# Altium schematic sheet ESP8266_Amp_SMD.SchDoc(ESP8266_Amp_SMD)
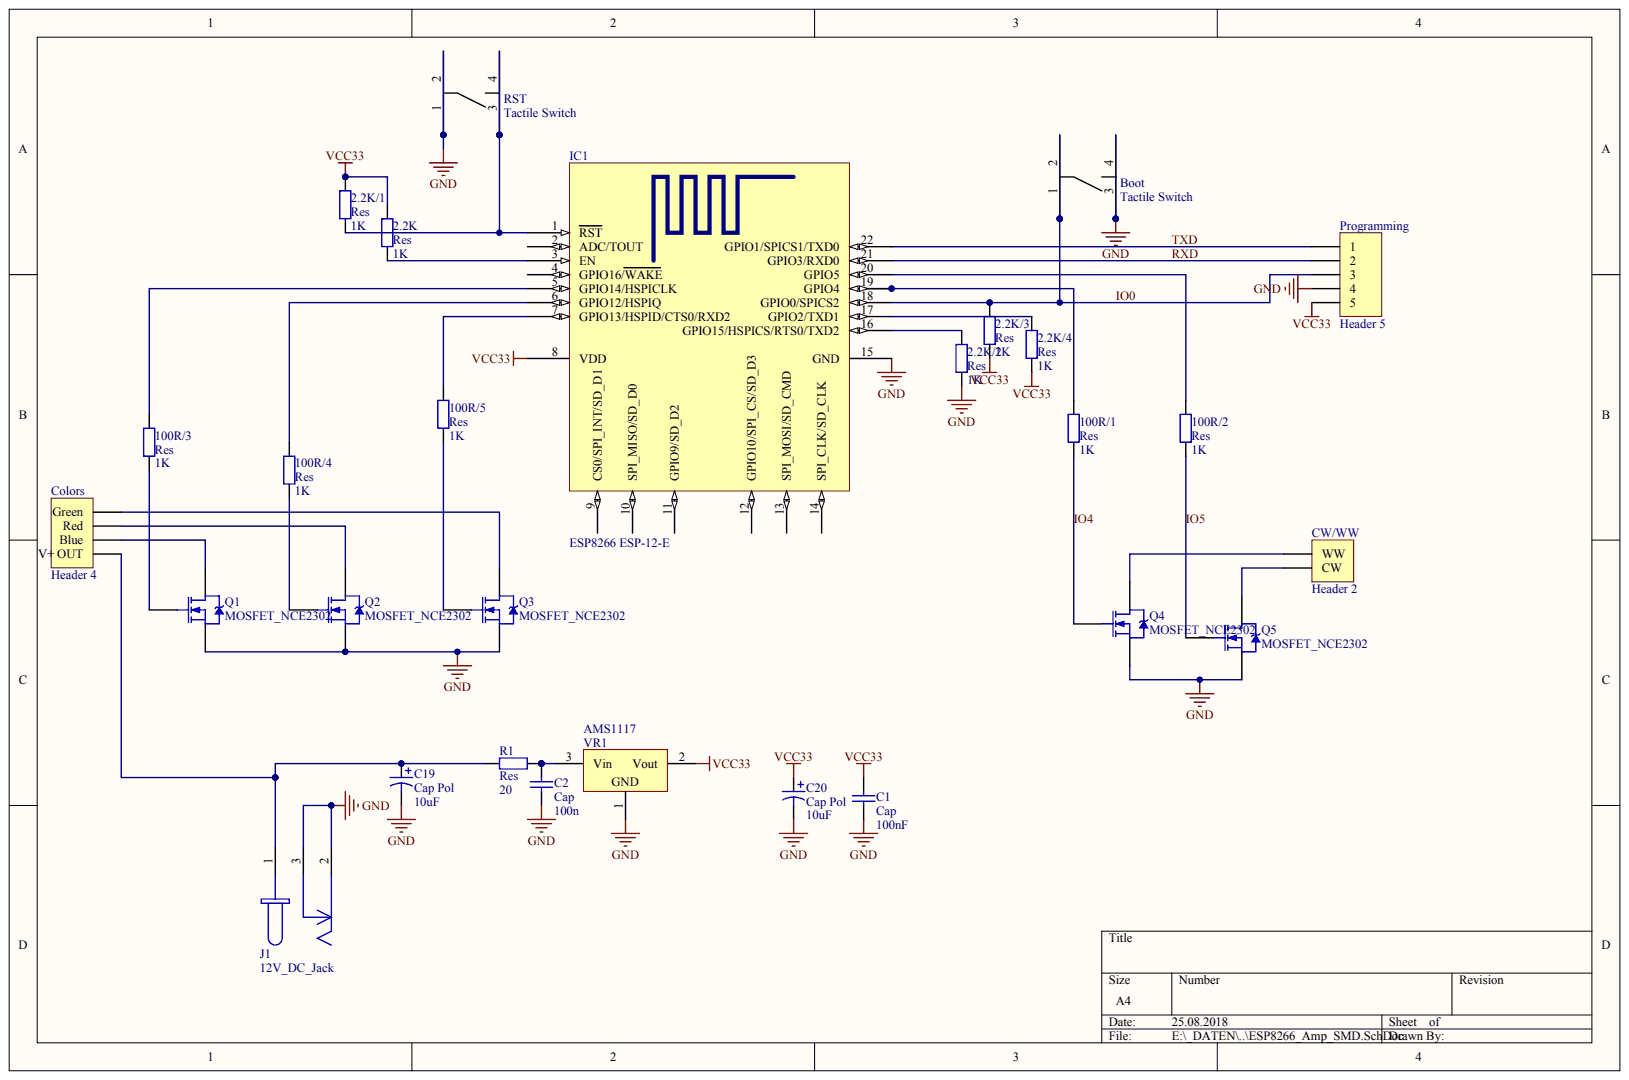
[diagram p0: ESP8266_Amp_SMD.SchDoc]

## Parts
2.2K  Res  value 1K
2.2K/1  Res  value 1K
2.2K/2  Res  value 1K
2.2K/3  Res  value 1K
2.2K/4  Res  value 1K
100R/1  Res  value 1K
100R/2  Res  value 1K
100R/3  Res  value 1K
100R/4  Res  value 1K
100R/5  Res  value 1K
Boot  Tactile Switch
C1  Cap  value 100nF
C2  Cap  value 100n
C19  Cap Pol  value 10uF
C20  Cap Pol  value 10uF
Colors  Header 4
CW/WW  Header 2
IC1  ESP8266 ESP-12-E
J1  12V_DC_Jack
Programming  Header 5
Q1  MOSFET_NCE2302
Q2  MOSFET_NCE2302
Q3  MOSFET_NCE2302
Q4  MOSFET_NCE2302
Q5  MOSFET_NCE2302
R1  Res  value 20
RST  Tactile Switch
VR1  AMS1117

## Nets
GND: 2.2K/2.1 Boot.3 Boot.4 C1.1 C2.1 C19.1 C20.1 IC1.15(GND) J1.2 J1.3 Programming.4 Q1.1 Q2.1 Q3.1 Q4.1 Q5.1 RST.1 RST.2 VR1.1
VCC33: 2.2K.2 2.2K/1.2 2.2K/3.1 2.2K/4.1 C1.2 C20.2 IC1.8(VDD) Programming.5 VR1.2
IO0: 2.2K/3.2 Boot.1 Boot.2 IC1.18(GPIO0/SPICS2) Programming.3
IO4: 100R/1.1 Q4.2
IO5: 100R/2.1 Q5.2
RXD: IC1.21(GPIO3/RXD0) Programming.2
TXD: IC1.22(GPIO1/SPICS1/TXD0) Programming.1
Net2.2K/1_1: 2.2K/1.1 IC1.1(RST) RST.3 RST.4
Net2.2K/2_2: 2.2K/2.2 IC1.16(GPIO15/HSPICS/RTS0/TXD2)
Net2.2K/4_2: 2.2K/4.2 IC1.17(GPIO2/TXD1)
Net2.2K_1: 2.2K.1 IC1.3(EN)
Net100R/1_2: 100R/1.2 IC1.19(GPIO4)
Net100R/2_2: 100R/2.2 IC1.20(GPIO5)
Net100R/3_1: 100R/3.1 Q1.2
Net100R/3_2: 100R/3.2 IC1.5(GPIO14/HSPICLK)
Net100R/4_1: 100R/4.1 Q2.2
Net100R/4_2: 100R/4.2 IC1.6(GPIO12/HSPIQ)
Net100R/5_1: 100R/5.1 Q3.2
Net100R/5_2: 100R/5.2 IC1.7(GPIO13/HSPID/CTS0/RXD2)
NetC2_2: C2.2 R1.2 VR1.3
NetC19_2: C19.2 Colors.1(OUT) J1.1 R1.1
NetColors_2: Colors.2(Blue) Q1.3
NetColors_3: Colors.3(Red) Q2.3
NetColors_4: Colors.4(Green) Q3.3
NetCW/WW_1: CW/WW.1 Q4.3
NetCW/WW_2: CW/WW.2 Q5.3

Not connected: IC1 2(ADC/TOUT) 4(GPIO16/WAKE) 9(CS0/SPI_INT/SD_D1) 10(SPI_MISO/SD_D0) 11(GPIO9/SD_D2) 12(GPIO10/SPI_CS/SD_D3) 13(SPI_MOSI/SD_CMD) 14(SPI_CLK/SD_CLK)

## Library data of the parts
Boot RST = (no symbol name): Value: 1K; Published: 8-Jun-2000; LatestRevisionDate: 17-Jul-2002; LatestRevisionNote: Re-released for DXP Platform.; PackageReference: AXIAL-0.4; PackageDescription: Axial Device, Thru-Hole; 2 Leads; 0.4 in Pin Spacing; Publisher: Altium Limited
J1 = (no symbol name): PartNumber: 694106301002; Published: June 2016; ComponentLink1Description: Manufacturer; ComponentLink1URL: http://www.we-online.de; ComponentLink2Description: Datasheet; ComponentLink2URL: http://katalog.we-online.de/em/datasheet/6941xx301002.pdf; Publisher: Wurth Elektronik
Q1-Q5 = (no symbol name): Note: Pin 2 is electrically connected to pin 4.; Published: 8-Jun-2000; LatestRevisionDate: 17-Jul-2002; LatestRevisionNote: Re-released for DXP Platform.; PackageReference: TO-251AA; PackageDescription: TO, Thru-Hole, Vertical, Heatsink Mounted; 3 In-Line Leads; Pitch 2.28 mm; ComponentLink1Description: Manufacturer Link; ComponentLink1URL: http://www.irf.com/; ComponentLink2Description: Datasheet; ComponentLink2URL: http://www.irf.com/product-info/datasheets/data/irlr024n.pdf; Publisher: Altium Limited
2.2K 2.2K/1-2.2K/4 100R/1-100R/5 C1 C2 C19 C20 Colors CW/WW IC1 Programming R1 VR1 = (no symbol name)
  2.2K 2.2K/1-2.2K/4 100R/1-100R/5 R1: Published: 8-Jun-2000; LatestRevisionDate: 17-Jul-2002; LatestRevisionNote: Re-released for DXP Platform.; PackageReference: AXIAL-0.4; PackageDescription: Axial Device, Thru-Hole; 2 Leads; 0.4 in Pin Spacing
  C1 C2: Published: 8-Jun-2000; LatestRevisionDate: 17-Jul-2002; LatestRevisionNote: Re-released for DXP Platform.; PackageReference: RAD-0.3; PackageDescription: Radial Cap, Thru-Hole; 2 Leads; 0.3 in Pin Spacing
  C19 C20: Published: 8-Jun-2000; LatestRevisionDate: 17-Jul-2002; LatestRevisionNote: Re-released for DXP Platform.; PackageReference: POLAR0.8; PackageDescription: Polarized Capacitor; 2 Leads
  Colors CW/WW Programming: LatestRevisionDate: 17-Jul-2002; LatestRevisionNote: Re-released for DXP Platform.
  VR1: Published: 24-Mar-1999; LatestRevisionDate: 29-May-2009; LatestRevisionNote: IPC-7351 Footprint Added.; PackageReference: D2PAK; PackageDescription: 3-Pin Transistor Outline Package 2.54 mm Pitch, 15.1 mm Lead Span
  2.2K 2.2K/1-2.2K/4 100R/1-100R/5 C1 C2 C19 C20 Colors CW/WW Programming R1 VR1: Publisher: Altium Limited
